# Altium schematic sheet Schematic.SchDoc
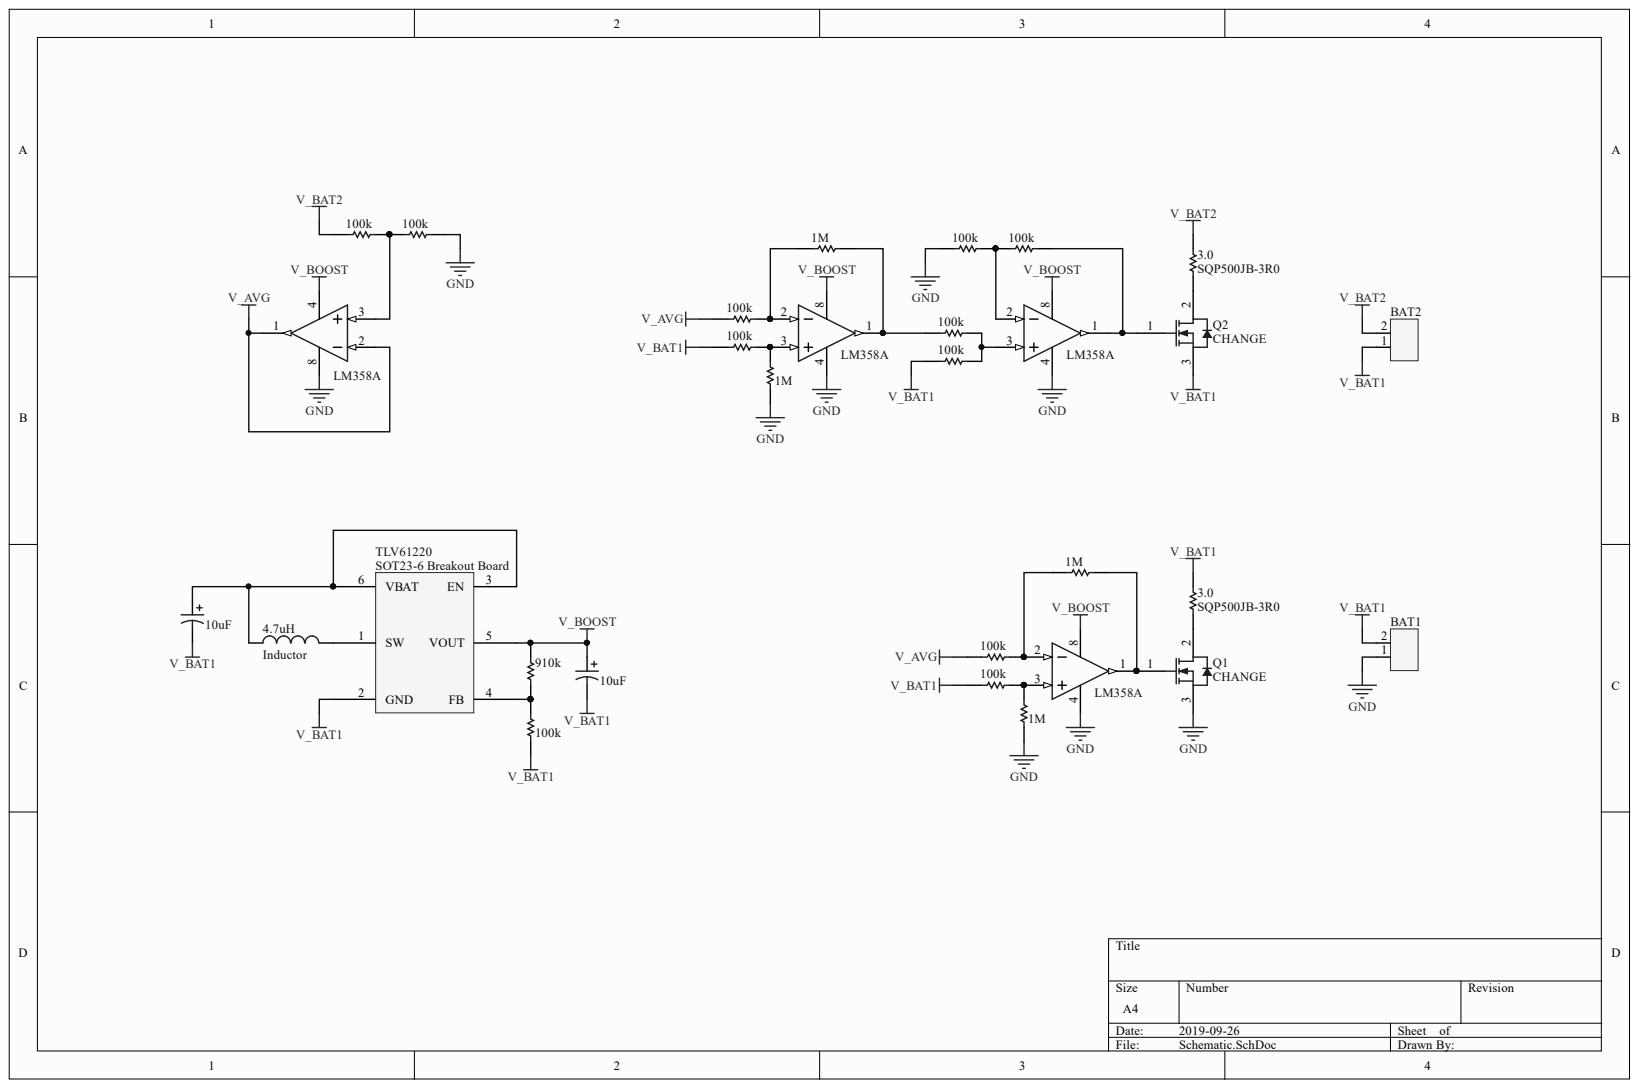
[diagram p0: Schematic.SchDoc]

## Parts
1M  1M  [PCB-2034605-1]
1M  1M  [PCB-2034605-1]
1M  1M  [PCB-2034605-1]
1M  1M  [PCB-2034605-1]
3.0  SQP500JB-3R0  [PCB-b86z09f1kqyy50n3gk9n-1]
3.0  SQP500JB-3R0  [PCB-b86z09f1kqyy50n3gk9n-1]
4.7uH  Inductor  [Inductor]
10uF  Cap Pol2  [Capacitor]  (value not drawn)
10uF  Cap Pol2  [Capacitor]  (value not drawn)
100k  100k  [PCB-d0rlwv34q6j96q41aa65-1]
100k  100k  [PCB-d0rlwv34q6j96q41aa65-1]
100k  100k  [PCB-d0rlwv34q6j96q41aa65-1]
100k  100k  [PCB-d0rlwv34q6j96q41aa65-1]
100k  100k  [PCB-d0rlwv34q6j96q41aa65-1]
100k  100k  [PCB-d0rlwv34q6j96q41aa65-1]
100k  100k  [PCB-d0rlwv34q6j96q41aa65-1]
100k  100k  [PCB-d0rlwv34q6j96q41aa65-1]
100k  100k  [PCB-d0rlwv34q6j96q41aa65-1]
100k  100k  [PCB-d0rlwv34q6j96q41aa65-1]
100k  100k  [PCB-d0rlwv34q6j96q41aa65-1]
910k  SYM-c0760be23e191fb1-1  [PCB-uqsvgli070t2b54uza93-1]  (value not drawn)
BAT1  TE Connectivity (Tyco Electronics) 282834-2  [PCB-6xrngco598u3mra2uzi2-1]  (value not drawn)
BAT2  TE Connectivity (Tyco Electronics) 282834-2  [PCB-6xrngco598u3mra2uzi2-1]  (value not drawn)
LM358  TI-LM358-8  [PCB-sh3andz6vjobp3klq69x-1]  (value not drawn)  (part A)
LM358  TI-LM358-8  [PCB-sh3andz6vjobp3klq69x-1]  (value not drawn)  (part A)
LM358  TI-LM358-8  [PCB-sh3andz6vjobp3klq69x-1]  (value not drawn)  (part A)
LM358  TI-LM358-8  [PCB-sh3andz6vjobp3klq69x-1]  (value not drawn)  (part A)
Q1  CHANGE  [NXP-SOT78]
Q2  CHANGE  [NXP-SOT78]
TLV61220  TLV61220  [MF06A_N]

## Nets
GND: 1M.1 100k.1 100k.2 BAT1.1 LM358.4 LM358.8 Q1.3
V_AVG: 100k.2 LM358.1 LM358.2
V_BAT1: 3.0.2 10uF.2 100k.1 100k.2 BAT1.2 BAT2.1 Q2.3 TLV61220.2(GND)
V_BAT2: 3.0.2 100k.2 BAT2.2
V_BOOST: 10uF.1 910k.2 LM358.4 LM358.8 TLV61220.5(VOUT)
Net1M_1: 1M.1 100k.1 LM358.2
Net1M_2: 1M.2 100k.1 100k.2 LM358.1 LM358.3 Q1.1
Net3.0_1: 3.0.1 Q1.2 Q2.2
Net4.7uH_1: 4.7uH.1 10uF.1 TLV61220.3(EN) TLV61220.6(VBAT)
Net4.7uH_2: 4.7uH.2 TLV61220.1(SW)
Net100k_1: 100k.1 100k.2 LM358.1 LM358.2 LM358.3 Q2.1
Net100k_2: 100k.2 910k.1 TLV61220.4(FB)

## Library data of the parts
1M 1M 1M 1M = RNF14FTD1M00: RNF Series 0.25 W 1 MOhm ±1 % ±100 ppm/°C General Purpose Metal Film Resistor; Lead-Free Status: Lead Free; Power Rating: 250 mW; Resistance: 1.00 MΩ; Resistance Tolerance: ±1%; Component Kind: Standard; Library Name: Octopart library; Manufacturer Part Number: RNF14FTD1M00; Mounting Style: Axial, Through Hole; OctopartID: dbfd13d2a606043a; Octopart URL: http://octopart.com/rnf14ftd1m00-stackpole+electronics-19224733; Packaging: Tape & Reel (TR); RoHS: Compliant
3.0 3.0 = SQP500JB-2R7: Res 2.7 Ohm 5w 5% Axial; Lead-Free Status: Lead Free; Resistance: 2.70 Ω; Voltage Rating (DC): 350 V; Component Kind: Standard; Library Name: Octopart library; Manufacturer Part Number: SQP500JB-2R7; Mounting Style: Through Hole; OctopartID: d5131c0f88760ad4; Octopart URL: http://octopart.com/sqp500jb-2r7-yageo-1472515; Packaging: Bulk; Resistance Tolerance: ±5%; RoHS: Compliant; Size-Height: 9.00 mm; Size-Length: 22.0 mm; Size-Width: 9.50 mm
4.7uH = 11R472C: Inductor General Purpose Wirewound 4.7uH 20% 1KHz 1.3A 90mOhm DCR RDL Bag; Lead-Free Status: Lead Free; Component Kind: Standard; Library Name: Octopart library; Manufacturer Part Number: 11R472C; Mounting Style: Through Hole; OctopartID: 15f8de00b168ca3f; Octopart URL: https://octopart.com/11r472c-murata+power+solutions-49447414; Packaging: Bulk
10uF 10uF = ECE-A1HKA100: Electrolytic capacitor Radial lead 2 mm 10 µF 50 V 20 % (Ø) 5 mm; Capacitance: 10.0 µF; Lead-Free Status: Lead Free; Component Kind: Standard; Library Name: Octopart library; Manufacturer Part Number: ECE-A1HKA100; Mounting Style: Through Hole; OctopartID: 2e19e5496191f1de; RoHS: Compliant; Size-Diameter: 5.00 mm; Size-Height: 7.00 mm
100k 100k 100k 100k 100k 100k 100k 100k 100k 100k 100k = CFM14JT100K: Res Carbon Film 100K Ohm 5% 0.25W(1/4W) -850ppm/?C to 0ppm/?C Conformal Coated AXL T/R; Component Kind: Standard; Library Name: Octopart library; Manufacturer Part Number: CFM14JT100K; Mounting Style: Axial, Through Hole; OctopartID: 678cafa352bcb84b; Octopart URL: http://octopart.com/cfm14jt100k-stackpole+electronics-19206230; Packaging: Tape & Reel (TR); Resistance Tolerance: ±5%; RoHS: Compliant
910k = CCF07910KGKE36: Res Metal Film 910K Ohm 2% 1/4W 1/2W ±100ppm/°C Conformal AXL Thru-Hole T/R; Resistance: 910 kΩ; Component Kind: Standard; Library Name: Octopart library; Manufacturer Part Number: CCF07910KGKE36; Mounting Style: Axial; OctopartID: c0760be23e191fb1; Octopart URL: https://octopart.com/ccf07910kgke36-vishay-43965193; Packaging: Reel
BAT1 BAT2 = 282834-2: Wire-To-Board Terminal Block, 2, 150 V, 10 A, 30 Awg, 16 Awg, 1.4 Mm; ELV Compliant: Compliant; Lead-Free Status: Lead Free; Number of Contacts: 2; Wire Gauge: 16.0 AWG to 30.0 AWG; Color: Green; Component Kind: Standard; Contact Material: Brass; Contact Plating: Tin; Flammability Rating: UL 94 V-0; Library Name: Octopart library; Mounting Style: Screw, Through Hole; Octopart URL: https://octopart.com/282834-2-te+connectivity-46506870; RoHS: Compliant; Size-Width: 6.50 mm
LM358 LM358 LM358 LM358 = LM358AP: SERVAL LM358; Manufacturer: Texas Instrument; Input Offset Voltage (Max): 9 mV; Input Voltage (Dual): ±1.5 V ~ ±16 V; Input Voltage (Single): 3 V ~ 32 V; Number of Elements: 2; Output Current: 20 mA; Component Kind: Standard; Library Name: Octopart library; Manufacturer Part Number: LM358AP; Package: DIP
Q1 Q2 = PSMN5R6-100PS,127: N-Channel Standard Level MOSFET, 100 V, 100 A, 3-Pin SOT78, Tube; Component Kind: Standard; Library Name: Octopart library; Lifecycle Status: Active; Manufacturer Part Number: PSMN5R6-100PS,127; Number of Pins: 3; OctopartID: c9350128bfb616f2; Octopart Url: https://octopart.com/psmn5r6-100ps%2C127-nxp+semiconductors-18306021; Polarity: N-Channel; RoHS: Compliant
TLV61220 = TLV61220DBVR: DC-DC CONV, BOOST, SOT-23-6; Primary Input Voltage: 5.5V; No. of Outputs: 1; Volta; Lead-Free Status: Lead Free; Number of Outputs: 1; Output Current: 0.2 A; Output Voltage: V; Quiescent Current: 5e-06 A; Case/Package: SOT-23; Component Kind: Standard; Library Name: Octopart library; Lifecycle Status: Active; Manufacturer Part Number: TLV61220DBVR; Number of Pins: 6; Number of Regulated Outputs: 1; OctopartID: e3772b1ed9253cb0; Octopart URL: http://octopart.com/tlv61220dbvr-texas+instruments-23273268; Packaging: Cut Tape (CT); REACH SVHC Compliance: No SVHC; RoHS: Compliant; Topology: Boost
